# Altium schematic sheet buck3.SchDoc
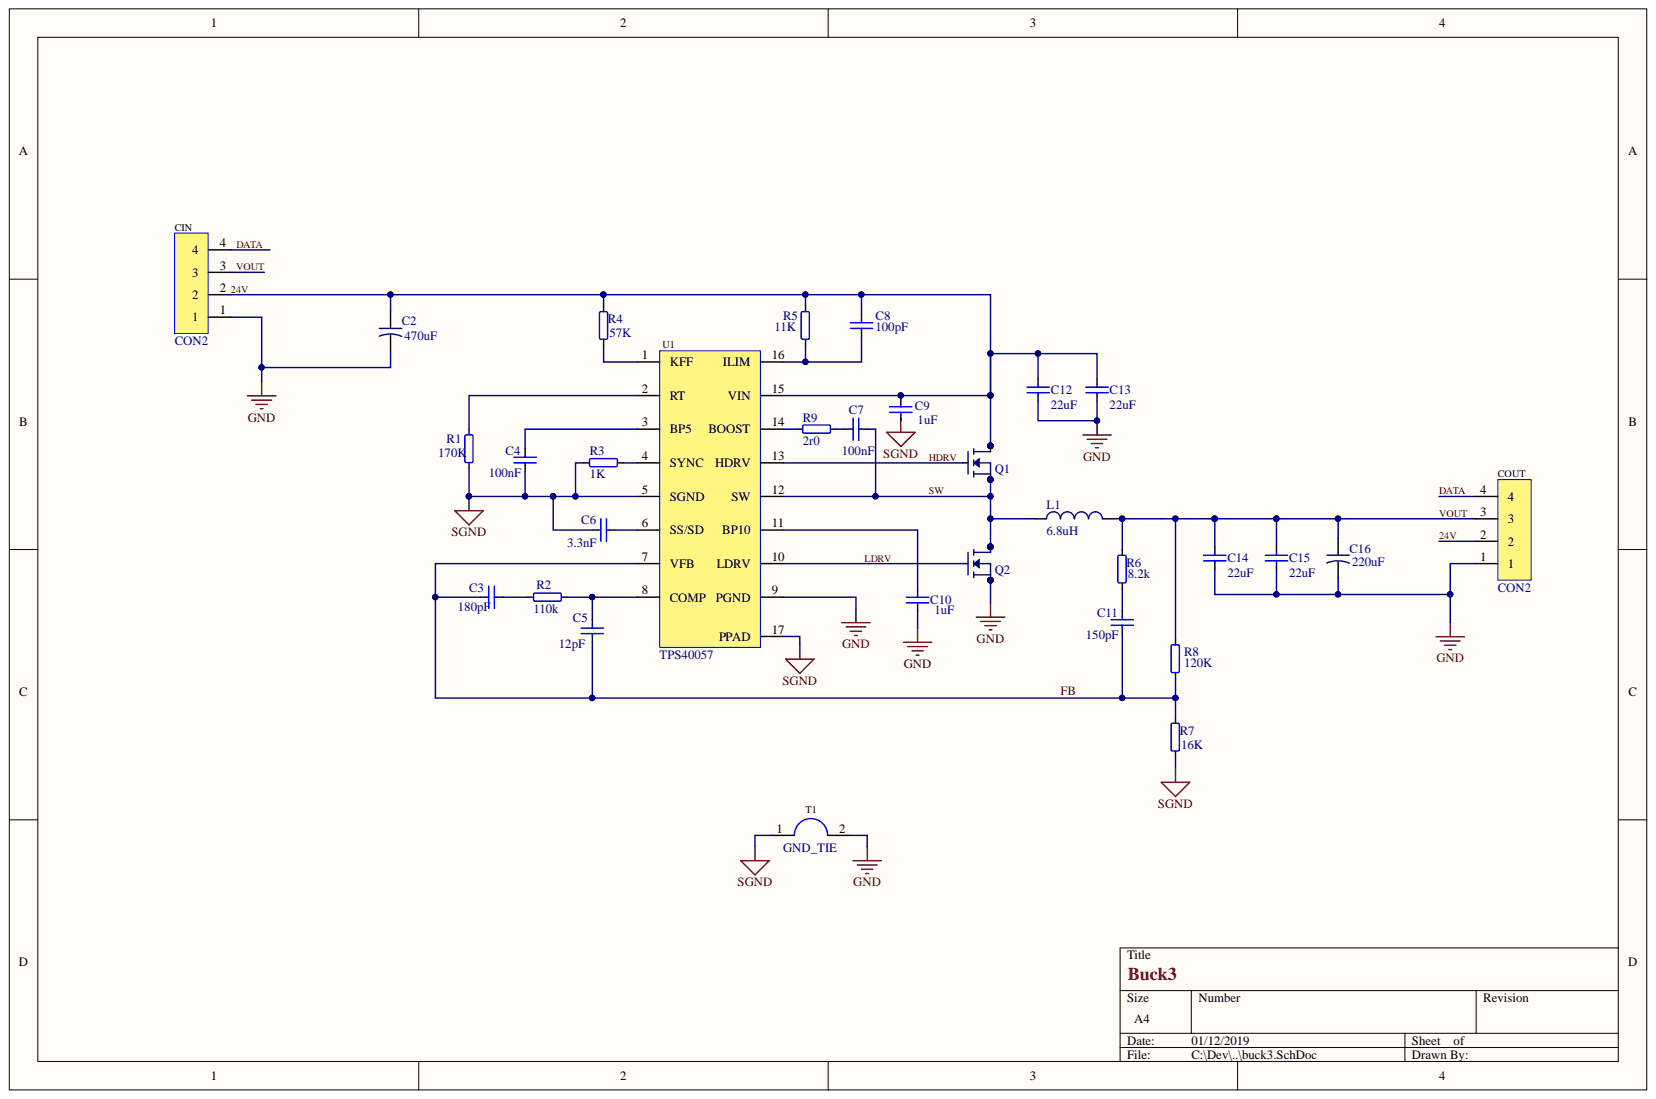
[diagram p0: buck3.SchDoc]

## Parts
C2  470uF  [CAPAE10083X104N]
C3  180pF  [CAPC 0603 1608X05L]
C4  100nF  [CAPC 0603 1608X05L]
C5  12pF  [CAPC 0603 1608X05L]
C6  3.3nF  [CAPC 0603 1608X05L]
C7  100nF  [CAPC 0603 1608X05L]
C8  100pF  [CAPC 0603 1608X05L]
C9  1uF  [CAPC 0603 1608X05L]
C10  1uF  [CAPC 0603 1608X05L]
C11  150pF  [CAPC 0603 1608X05L]
C12  22uF  [CAPC 1210]
C13  22uF  [CAPC 1210]
C14  22uF  [CAPC 1210]
C15  22uF  [CAPC 1210]
C16  220uF  [CAPAE10083X104N]
CIN  CON2  [CON2]  (no BOM)
COUT  CON2  [CON2]  (no BOM)
L1  value 6.8uH  [INDP125125X80L]  (hidden comment: Inductor)
Q1  Infineon BSC093N04LSGATMA1  [INF-PG-TDSON-8-1_V]  (value not drawn)
Q2  Infineon BSC093N04LSGATMA1  [INF-PG-TDSON-8-1_V]  (value not drawn)
R1  170K  [RESC 0603 1608X05L]
R2  110k  [RESC 0603 1608X05L]
R3  1K  [RESC 0603 1608X05L]
R4  57K  [RESC 0603 1608X05L]
R5  11K  [RESC 0603 1608X05L]
R6  8.2k  [RESC 0603 1608X05L]
R7  16K  [RESC 0603 1608X05L]
R8  120K  [RESC 0603 1608X05L]
R9  2r0  [RESC 0603 1608X05L]
T1  GND_TIE  [GND_TIE]
U1  TPS40057  [TSOP65P640X120_HS-17N]

## Nets
GND: C2.2 C10.1 C12.1 C13.1 C14.1 C15.1 C16.2 CIN.1 COUT.1 Q2.1 Q2.2 Q2.3 T1.2 U1.9(PGND)
SGND: C4.1 C6.1 C9.1 R1.1 R3.1 R7.1 T1.1 U1.5(SGND) U1.17(PPAD)
24V: C2.1 C8.2 C9.2 C12.2 C13.2 CIN.2 COUT.2 Q1.5 Q1.6 Q1.7 Q1.8 R4.1 R5.1 U1.15(VIN)
DATA: CIN.4 COUT.4
FB: C3.2 C5.2 C11.2 R7.2 R8.1 U1.7(VFB)
HDRV: Q1.4 U1.13(HDRV)
LDRV: Q2.4 U1.10(LDRV)
SW: C7.1 L1.1 Q1.1 Q1.2 Q1.3 Q2.5 Q2.6 Q2.7 Q2.8 U1.12(SW)
VOUT: C14.2 C15.2 C16.1 CIN.3 COUT.3 L1.2 R6.2 R8.2
NetC3_1: C3.1 R2.1
NetC4_2: C4.2 U1.3(BP5)
NetC5_1: C5.1 R2.2 U1.8(COMP)
NetC6_2: C6.2 U1.6(SS/SD)
NetC7_2: C7.2 R9.2
NetC8_1: C8.1 R5.2 U1.16(ILIM)
NetC10_2: C10.2 U1.11(BP10)
NetC11_1: C11.1 R6.1
NetR1_2: R1.2 U1.2(RT)
NetR3_2: R3.2 U1.4(SYNC)
NetR4_2: R4.2 U1.1(KFF)
NetR9_1: R9.1 U1.14(BOOST)

## Library data of the parts
C2 C16 = E CAP: Capacitor; ClassName: caps; Component Kind: Standard; Library Name: buck3.SchLib
  C2: Value: 470uF
  C16: Value: 220uF
C3-C15 = Cap: Capacitor; Component Kind: Standard; LatestRevisionDate: 17-Jul-2002; LatestRevisionNote: Re-released for DXP Platform.; PackageDescription: Radial Cap, Thru-Hole; 2 Leads; 0.3 in Pin Spacing; PackageReference: RAD-0.3; Published: 8-Jun-2000; Publisher: Altium Limited
  C3: Library Name: buck3.SchLib; Value: 180pF
  C4 C7: Library Name: buck3.SchLib; Value: 100nF
  C5: Library Name: buck3.SchLib; Value: 12pF
  C6: Library Name: buck3.SchLib; Value: 3.3nF
  C8: Library Name: buck3.SchLib; Value: 100pF
  C9 C10: Library Name: buck3.SchLib; Value: 1uF
  C11: Library Name: buck3.SchLib; Value: 150pF
  C12 C13: Library Name: buck3.SCHLIB; Value: 22uF
  C14 C15: ClassName: caps; Library Name: buck3.SCHLIB; Value: 22uF
L1 = Inductor: Code_IEC: 1005; Code_JEITA: 0402; Component Kind: Standard; Description: Inductor; LatestRevisionDate: 29-May-2009; LatestRevisionNote: IPC-7351 Footprint Added.; Library Name: buck3.SCHLIB; PackageDescription: Chip Inductor; PackageReference: 0402-A; Published: 8-Jun-2000; Publisher: Altium Limited
Q1 Q2 = MOSFET-N: N Channel MOSFET; Component Kind: Standard; Library Name: buck3.SCHLIB; Value: Infineon BSC093N04LSGATMA1
R1-R9 = Res2: Resistor; Component Kind: Standard; LatestRevisionDate: 17-Jul-2002; LatestRevisionNote: Re-released for DXP Platform.; PackageDescription: Axial Device, Thru-Hole; 2 Leads; 0.4 in Pin Spacing; PackageReference: AXIAL-0.4; Published: 8-Jun-2000; Publisher: Altium Limited
  R1: Library Name: buck3.SchLib; Value: 170K
  R2: Library Name: buck3.SchLib; Value: 110k
  R3: Library Name: buck3.SchLib; Value: 1K
  R4: Library Name: buck3.SchLib; Value: 57K
  R5: Library Name: buck3.SchLib; Value: 11K
  R6: Library Name: buck3.SchLib; Value: 8.2k
  R7: Library Name: buck3.SchLib; Value: 16K
  R8: Library Name: buck3.SchLib; Value: 120K
  R9: Library Name: buck3.SCHLIB; Value: 2r0
U1 = TPS40057: Buck Controller; Component Kind: Standard; Library Name: buck3.SchLib; Value: TPS40057
CIN COUT = CON2: Library Name: buck3.SchLib
T1 = GND_TIE: Component Kind: Net Tie; Library Name: buck3.SchLib
